# Altium schematic sheet [03] - MCU.SchDoc
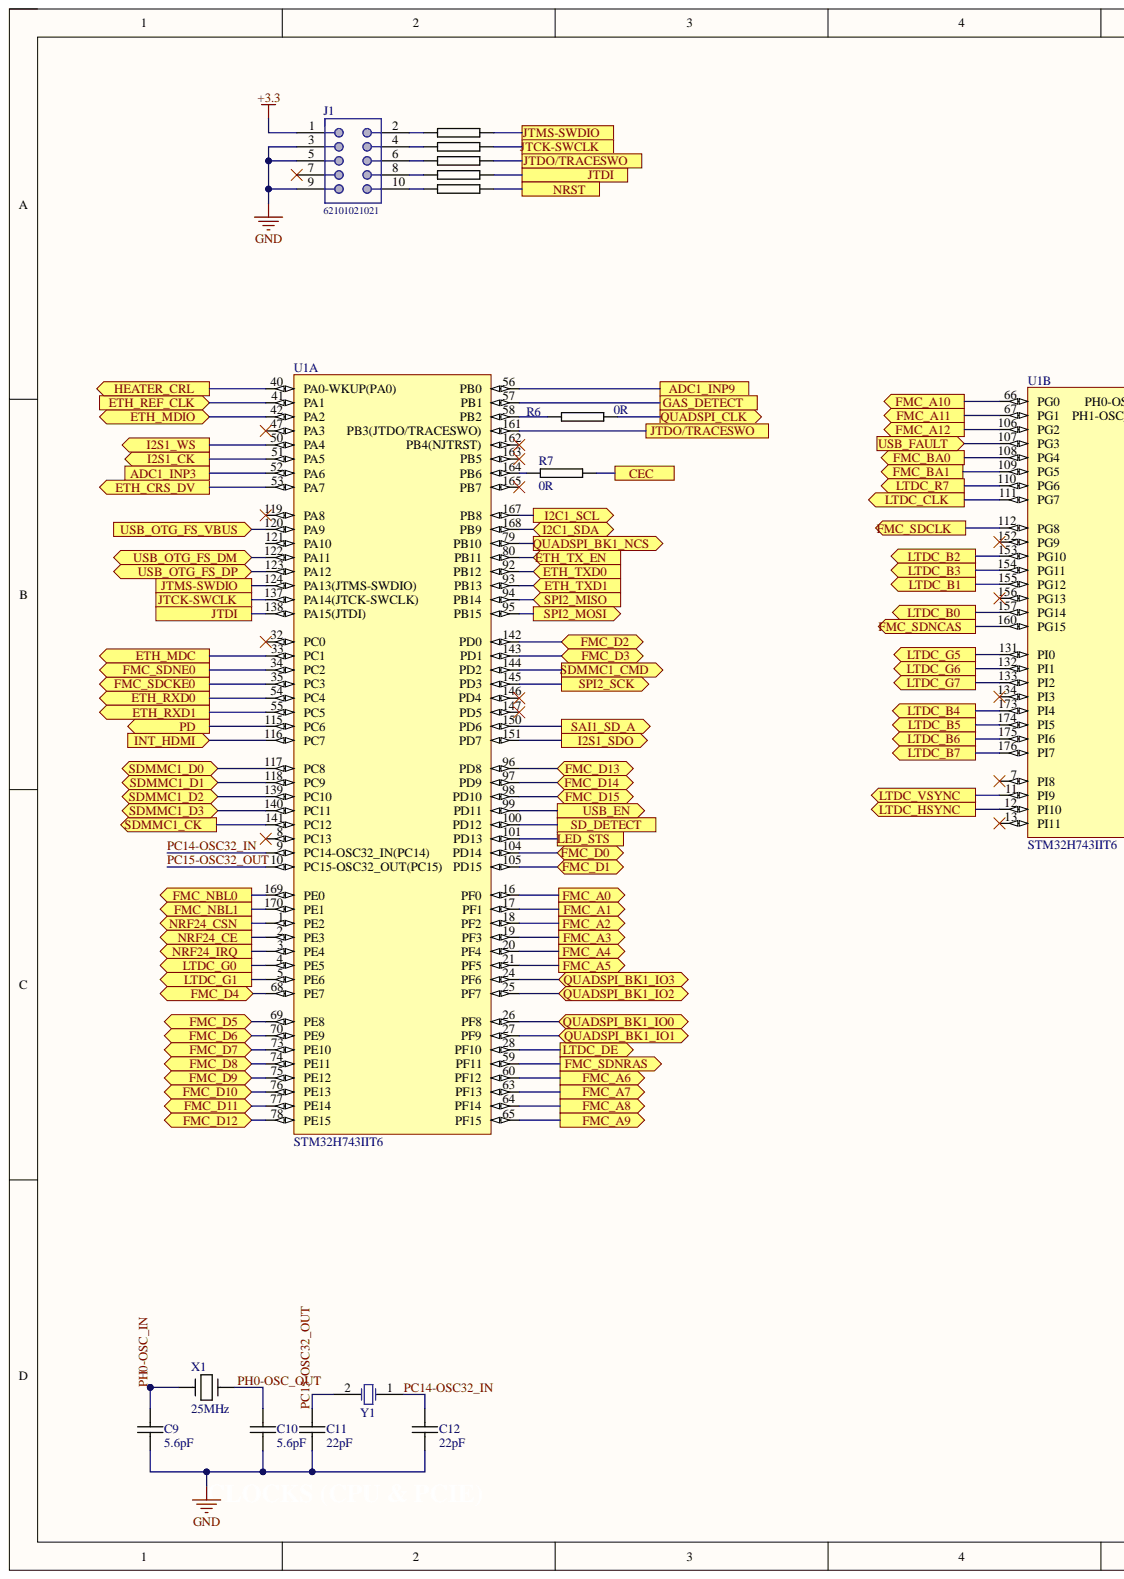
[diagram p0: [03] - MCU.SchDoc, left]
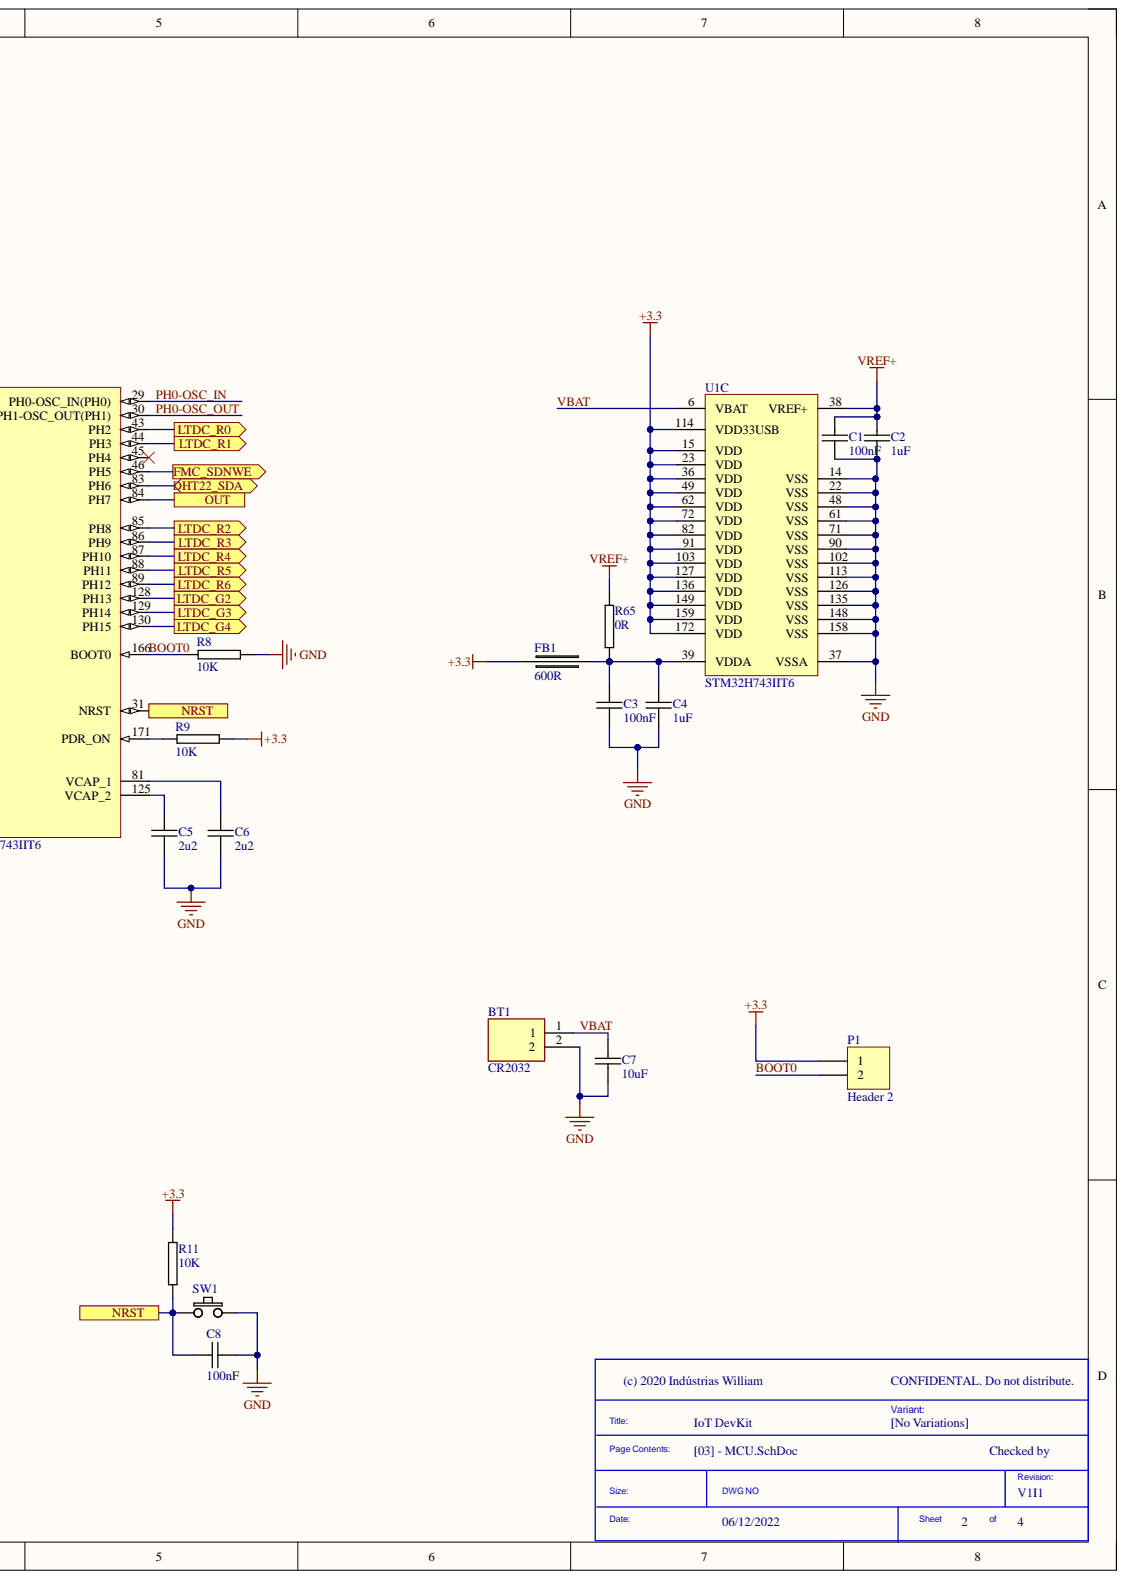
[diagram p1: [03] - MCU.SchDoc, right]

## Parts
BT1  CR2032  [socket_CR2032]
C1  100nF  [0603C-GENERIC]
C2  1uF  [0603C-GENERIC]
C3  100nF  [0603C-GENERIC]
C4  1uF  [0603C-GENERIC]
C5  2u2  [0603C-2U2]
C6  2u2  [0603C-2U2]
C7  10uF  [0603C-GENERIC]
C8  100nF  [0603C-GENERIC]
C9  5.6pF  [0603C-GENERIC]
C10  5.6pF  Reel: C10  [0603C-GENERIC]
C11  22pF  Reel: C10  [0603C-GENERIC]
C12  22pF  [0603C-GENERIC]
FB1  600R  [0603FB-GENERIC]
J1  62101021021  [62101021021]
P1  Header 2  [HDR1X2]
R1  33R  [0603R-33]  (value not drawn)
R2  33R  [0603R-33]  (value not drawn)
R3  33R  [0603R-33]  (value not drawn)
R4  33R  [0603R-33]  (value not drawn)
R5  33R  [0603R-33]  (value not drawn)
R6  0R  [0603R-0R]
R7  0R  [0603R-0R]
R8  10K  [0603R-10K]
R9  10K  [0603R-10K]
R11  10K  [0603R-10K]
R65  0R  [0603R-0R]
SW1  SW-PB-BLACK-SMD  [SW-PB-SMD]  (value not drawn)
U1  STM32H743IIT6  [STM-LQFP176_N]  (parts A,B,C)
X1  25MHz  [5032]
Y1  32.768kHz, +/-20ppm, 12.5pF  [WE-XTAL_CFPX-56]  (value not drawn)

## Ports
ADC1_INP3, ADC1_INP9, CEC, DHT22_SDA, ETH_CRS_DV, ETH_MDC, ETH_MDIO, ETH_REF_CLK, ETH_RXD0, ETH_RXD1, ETH_TX_EN, ETH_TXD0, ETH_TXD1, FMC_A0, FMC_A1, FMC_A2, FMC_A3, FMC_A4, FMC_A5, FMC_A6, FMC_A7, FMC_A8, FMC_A9, FMC_A10, FMC_A11, FMC_A12, FMC_BA0, FMC_BA1, FMC_D0, FMC_D1, FMC_D2, FMC_D3, FMC_D4, FMC_D5, FMC_D6, FMC_D7, FMC_D8, FMC_D9, FMC_D10, FMC_D11, FMC_D12, FMC_D13, FMC_D14, FMC_D15, FMC_NBL0, FMC_NBL1, FMC_SDCKE0, FMC_SDCLK, FMC_SDNCAS, FMC_SDNE0, FMC_SDNRAS, FMC_SDNWE, GAS_DETECT, HEATER_CRL, I2C1_SCL, I2C1_SDA, I2S1_CK, I2S1_SDO, I2S1_WS, INT_HDMI, JTCK-SWCLK, JTDI, JTDO/TRACESWO, JTMS-SWDIO, LED_STS, LTDC_B0, LTDC_B1, LTDC_B2, LTDC_B3, LTDC_B4, LTDC_B5, LTDC_B6, LTDC_B7, LTDC_CLK, LTDC_DE, LTDC_G0, LTDC_G1, LTDC_G2, LTDC_G3, LTDC_G4, LTDC_G5, LTDC_G6, LTDC_G7, LTDC_HSYNC, LTDC_R0, LTDC_R1, LTDC_R2, LTDC_R3, LTDC_R4, LTDC_R5, LTDC_R6, LTDC_R7, LTDC_VSYNC, NRF24_CE, NRF24_CSN, NRF24_IRQ, NRST, OUT, PD, QUADSPI_BK1_IO0, QUADSPI_BK1_IO1, QUADSPI_BK1_IO2, QUADSPI_BK1_IO3, QUADSPI_BK1_NCS, QUADSPI_CLK, SAI1_SD_A, SD_DETECT, SDMMC1_CK, SDMMC1_CMD, SDMMC1_D0, SDMMC1_D1, SDMMC1_D2, SDMMC1_D3, SPI2_MISO, SPI2_MOSI, SPI2_SCK, USB_EN, USB_FAULT, USB_OTG_FS_DM, USB_OTG_FS_DP, USB_OTG_FS_VBUS

## Nets
+3.3: FB1.1 J1.1 P1.1 R9.2 R11.2 U1.15(VDD) U1.23(VDD) U1.36(VDD) U1.49(VDD) U1.62(VDD) U1.72(VDD) U1.82(VDD) U1.91(VDD) U1.103(VDD) U1.114(VDD33USB) U1.127(VDD) U1.136(VDD) U1.149(VDD) U1.159(VDD) U1.172(VDD)
GND: BT1.2 C1.2 C2.2 C3.2 C4.2 C5.2 C6.2 C7.2 C8.2 C9.2 C10.2 C11.2 C12.2 J1.3 J1.5 J1.9 R8.2 SW1.2 U1.14(VSS) U1.22(VSS) U1.37(VSSA) U1.48(VSS) U1.61(VSS) U1.71(VSS) U1.90(VSS) U1.102(VSS) U1.113(VSS) U1.126(VSS) U1.135(VSS) U1.148(VSS) U1.158(VSS)
VREF+: C1.1 C2.1 R65.1 U1.38(VREF+)
ADC1_INP3: U1.52(PA6) ; port ADC1_INP3
ADC1_INP9: U1.56(PB0) ; port ADC1_INP9
BOOT0: P1.2 R8.1 U1.166(BOOT0)
CEC: R7.2 ; port CEC
DHT22_SDA: U1.83(PH6) ; port DHT22_SDA
ETH_CRS_DV: U1.53(PA7) ; port ETH_CRS_DV
ETH_MDC: U1.33(PC1) ; port ETH_MDC
ETH_MDIO: U1.42(PA2) ; port ETH_MDIO
ETH_REF_CLK: U1.41(PA1) ; port ETH_REF_CLK
ETH_RXD0: U1.54(PC4) ; port ETH_RXD0
ETH_RXD1: U1.55(PC5) ; port ETH_RXD1
ETH_TX_EN: U1.80(PB11) ; port ETH_TX_EN
ETH_TXD0: U1.92(PB12) ; port ETH_TXD0
ETH_TXD1: U1.93(PB13) ; port ETH_TXD1
FMC_A0: U1.16(PF0) ; port FMC_A0
FMC_A1: U1.17(PF1) ; port FMC_A1
FMC_A2: U1.18(PF2) ; port FMC_A2
FMC_A3: U1.19(PF3) ; port FMC_A3
FMC_A4: U1.20(PF4) ; port FMC_A4
FMC_A5: U1.21(PF5) ; port FMC_A5
FMC_A6: U1.60(PF12) ; port FMC_A6
FMC_A7: U1.63(PF13) ; port FMC_A7
FMC_A8: U1.64(PF14) ; port FMC_A8
FMC_A9: U1.65(PF15) ; port FMC_A9
FMC_A10: U1.66(PG0) ; port FMC_A10
FMC_A11: U1.67(PG1) ; port FMC_A11
FMC_A12: U1.106(PG2) ; port FMC_A12
FMC_BA0: U1.108(PG4) ; port FMC_BA0
FMC_BA1: U1.109(PG5) ; port FMC_BA1
FMC_D0: U1.104(PD14) ; port FMC_D0
FMC_D1: U1.105(PD15) ; port FMC_D1
FMC_D2: U1.142(PD0) ; port FMC_D2
FMC_D3: U1.143(PD1) ; port FMC_D3
FMC_D4: U1.68(PE7) ; port FMC_D4
FMC_D5: U1.69(PE8) ; port FMC_D5
FMC_D6: U1.70(PE9) ; port FMC_D6
FMC_D7: U1.73(PE10) ; port FMC_D7
FMC_D8: U1.74(PE11) ; port FMC_D8
FMC_D9: U1.75(PE12) ; port FMC_D9
FMC_D10: U1.76(PE13) ; port FMC_D10
FMC_D11: U1.77(PE14) ; port FMC_D11
FMC_D12: U1.78(PE15) ; port FMC_D12
FMC_D13: U1.96(PD8) ; port FMC_D13
FMC_D14: U1.97(PD9) ; port FMC_D14
FMC_D15: U1.98(PD10) ; port FMC_D15
FMC_NBL0: U1.169(PE0) ; port FMC_NBL0
FMC_NBL1: U1.170(PE1) ; port FMC_NBL1
FMC_SDCKE0: U1.35(PC3) ; port FMC_SDCKE0
FMC_SDCLK: U1.112(PG8) ; port FMC_SDCLK
FMC_SDNCAS: U1.160(PG15) ; port FMC_SDNCAS
FMC_SDNE0: U1.34(PC2) ; port FMC_SDNE0
FMC_SDNRAS: U1.59(PF11) ; port FMC_SDNRAS
FMC_SDNWE: U1.46(PH5) ; port FMC_SDNWE
GAS_DETECT: U1.57(PB1) ; port GAS_DETECT
HEATER_CRL: U1.40(PA0-WKUP(PA0)) ; port HEATER_CRL
I2C1_SCL: U1.167(PB8) ; port I2C1_SCL
I2C1_SDA: U1.168(PB9) ; port I2C1_SDA
I2S1_CK: U1.51(PA5) ; port I2S1_CK
I2S1_SDO: U1.151(PD7) ; port I2S1_SDO
I2S1_WS: U1.50(PA4) ; port I2S1_WS
INT_HDMI: U1.116(PC7) ; port INT_HDMI
JTCK-SWCLK: R2.1 U1.137(PA14(JTCK-SWCLK)) ; port JTCK-SWCLK
JTDI: R4.1 U1.138(PA15(JTDI)) ; port JTDI
JTDO/TRACESWO: R3.1 U1.161(PB3(JTDO/TRACESWO)) ; port JTDO/TRACESWO
JTMS-SWDIO: R1.1 U1.124(PA13(JTMS-SWDIO)) ; port JTMS-SWDIO
LED_STS: U1.101(PD13) ; port LED_STS
LTDC_B0: U1.157(PG14) ; port LTDC_B0
LTDC_B1: U1.155(PG12) ; port LTDC_B1
LTDC_B2: U1.153(PG10) ; port LTDC_B2
LTDC_B3: U1.154(PG11) ; port LTDC_B3
LTDC_B4: U1.173(PI4) ; port LTDC_B4
LTDC_B5: U1.174(PI5) ; port LTDC_B5
LTDC_B6: U1.175(PI6) ; port LTDC_B6
LTDC_B7: U1.176(PI7) ; port LTDC_B7
LTDC_CLK: U1.111(PG7) ; port LTDC_CLK
LTDC_DE: U1.28(PF10) ; port LTDC_DE
LTDC_G0: U1.4(PE5) ; port LTDC_G0
LTDC_G1: U1.5(PE6) ; port LTDC_G1
LTDC_G2: U1.128(PH13) ; port LTDC_G2
LTDC_G3: U1.129(PH14) ; port LTDC_G3
LTDC_G4: U1.130(PH15) ; port LTDC_G4
LTDC_G5: U1.131(PI0) ; port LTDC_G5
LTDC_G6: U1.132(PI1) ; port LTDC_G6
LTDC_G7: U1.133(PI2) ; port LTDC_G7
LTDC_HSYNC: U1.12(PI10) ; port LTDC_HSYNC
LTDC_R0: U1.43(PH2) ; port LTDC_R0
LTDC_R1: U1.44(PH3) ; port LTDC_R1
LTDC_R2: U1.85(PH8) ; port LTDC_R2
LTDC_R3: U1.86(PH9) ; port LTDC_R3
LTDC_R4: U1.87(PH10) ; port LTDC_R4
LTDC_R5: U1.88(PH11) ; port LTDC_R5
LTDC_R6: U1.89(PH12) ; port LTDC_R6
LTDC_R7: U1.110(PG6) ; port LTDC_R7
LTDC_VSYNC: U1.11(PI9) ; port LTDC_VSYNC
NRF24_CE: U1.2(PE3) ; port NRF24_CE
NRF24_CSN: U1.1(PE2) ; port NRF24_CSN
NRF24_IRQ: U1.3(PE4) ; port NRF24_IRQ
NRST: C8.1 R5.1 R11.1 SW1.1 U1.31(NRST) ; port NRST
OUT: U1.84(PH7) ; port OUT
PC14-OSC32_IN: C12.1 U1.9(PC14-OSC32_IN(PC14)) Y1.1
PC15-OSC32_OUT: C11.1 U1.10(PC15-OSC32_OUT(PC15)) Y1.2
PD: U1.115(PC6) ; port PD
PH0-OSC_IN: C9.1 U1.29(PH0-OSC_IN(PH0)) X1.1
PH0-OSC_OUT: C10.1 U1.30(PH1-OSC_OUT(PH1)) X1.2
QUADSPI_BK1_IO0: U1.26(PF8) ; port QUADSPI_BK1_IO0
QUADSPI_BK1_IO1: U1.27(PF9) ; port QUADSPI_BK1_IO1
QUADSPI_BK1_IO2: U1.25(PF7) ; port QUADSPI_BK1_IO2
QUADSPI_BK1_IO3: U1.24(PF6) ; port QUADSPI_BK1_IO3
QUADSPI_BK1_NCS: U1.79(PB10) ; port QUADSPI_BK1_NCS
QUADSPI_CLK: R6.2 ; port QUADSPI_CLK
SAI1_SD_A: U1.150(PD6) ; port SAI1_SD_A
SD_DETECT: U1.100(PD12) ; port SD_DETECT
SDMMC1_CK: U1.141(PC12) ; port SDMMC1_CK
SDMMC1_CMD: U1.144(PD2) ; port SDMMC1_CMD
SDMMC1_D0: U1.117(PC8) ; port SDMMC1_D0
SDMMC1_D1: U1.118(PC9) ; port SDMMC1_D1
SDMMC1_D2: U1.139(PC10) ; port SDMMC1_D2
SDMMC1_D3: U1.140(PC11) ; port SDMMC1_D3
SPI2_MISO: U1.94(PB14) ; port SPI2_MISO
SPI2_MOSI: U1.95(PB15) ; port SPI2_MOSI
SPI2_SCK: U1.145(PD3) ; port SPI2_SCK
USB_EN: U1.99(PD11) ; port USB_EN
USB_FAULT: U1.107(PG3) ; port USB_FAULT
USB_OTG_FS_DM: U1.122(PA11) ; port USB_OTG_FS_DM
USB_OTG_FS_DP: U1.123(PA12) ; port USB_OTG_FS_DP
USB_OTG_FS_VBUS: U1.120(PA9) ; port USB_OTG_FS_VBUS
VBAT: BT1.1 C7.1 U1.6(VBAT)
NetC3_1: C3.1 C4.1 FB1.2 R65.2 U1.39(VDDA)
NetC5_1: C5.1 U1.125(VCAP_2)
NetC6_1: C6.1 U1.81(VCAP_1)
NetJ1_2: J1.2 R1.2
NetJ1_4: J1.4 R2.2
NetJ1_6: J1.6 R3.2
NetJ1_8: J1.8 R4.2
NetJ1_10: J1.10 R5.2
NetR6_1: R6.1 U1.58(PB2)
NetR7_1: R7.1 U1.164(PB6)
NetR9_1: R9.1 U1.171(PDR_ON)

Not connected: J1 7; U1 7(PI8) 8(PC13) 13(PI11) 32(PC0) 45(PH4) 47(PA3) 119(PA8) 121(PA10) 134(PI3) 146(PD4) 147(PD5) 152(PG9) 156(PG13) 162(PB4(NJTRST)) 163(PB5) 165(PB7)

## Library data of the parts
BT1 = CR2032: Conector Battery CR2032; Manufacturer_Name: Wurth Elektronik; Manufacturer_Part_Number: 79527141; Arrow Part Number: 79527141; Component Kind: Standard; Datasheet Link: http://www.mouser.com/datasheet/2/445/79527141-1380512.pdf; Height: 5.9mm; Mouser Part Number: 710-79527141; Mouser Price/Stock: https://www.mouser.co.uk/ProductDetail/Wurth-Elektronik/79527141?qs=wr8lucFkNMXHgRaBtMvyBw%3D%3D; Library Name: Componentes Deived Projetos.IntLib
C1 C3 C8 = 0603C-100NF-25V-X7R-TDK: CAPACITOR 0603 CERAMIC; Manufacturer: TDK Corporation; Manufacturer Part Number: C1608X7R1E104K; Reel: C12; Component Kind: Standard; Library Name: vh_altium_library.intLib; Supplier 1: Digi-Key; Supplier Part Number 1: 445-1316-2-ND
C2 C4 = 0603C-1UF-10V-X5R-TDK: CAPACITOR 0603 CERAMIC; Manufacturer: TDK Corporation; Manufacturer Part Number: C1608X5R1A105K; Reel: C23; Component Kind: Standard; Library Name: vh_altium_library.intLib; Supplier 1: Digi-Key; Supplier Part Number 1: 445-1321-2-ND
C5 C6 = 0603C-2U2-10V-X5R-TDK: CAPACITOR 0603 CERAMIC; Manufacturer: TDK Corporation; Manufacturer Part Number: C1608X5R1A225K; Reel: C11; Component Kind: Standard; Library Name: vh_altium_library.intLib; Supplier 1: Digi-Key; Supplier Part Number 1: 445-5166-2-ND
C7 = 0603C-10UF-10V-X5R-TDK: CAPACITOR 0603 CERAMIC; Manufacturer: TDK Corporation; Manufacturer Part Number: C1608X5R0J106M; Reel: C31; Component Kind: Standard; Library Name: vh_altium_library.intLib; Supplier 1: Digi-Key; Supplier Part Number 1: 445-4112-2-ND
C9 = 0603C-22PF-50V-C0G-PAN: CAPACITOR 0603 CERAMIC; Manufacturer: Panasonic - ECG; Manufacturer Part Number: ECJ-1VC1H220J; Capacitance: 22pF; Reel: C10; Temperature Coefficient: C0G, NP0; Tolerance: ±5%; Voltage - Rated: 50V; Category: Capacitors; Component Kind: Standard; Features: General Purpose; Lead Spacing: -; Library Name: vh_altium_library.intLib; Mounting Type: Surface Mount, MLCC; Operating Temperature: -55°C ~ 125°C; Package / Case: 0603 (1608 metric); Packaging: Tape & Reel (TR); RoHS: RoHS Compliant; Supplier: Digi-Key; Supplier 1: Digi-Key; Supplier Part Number: PCC220ACVTR-ND; Supplier Part Number 1: PCC220ACVTR-ND; Thickness: 0.80mm
C10 = 0603C-22PF-50V-C0G-PAN: CAPACITOR 0603 CERAMIC; Manufacturer: Panasonic - ECG; Manufacturer Part Number: ECJ-1VC1H220J; Capacitance: 22pF; Temperature Coefficient: C0G, NP0; Tolerance: ±5%; Voltage - Rated: 50V; Category: Capacitors; Component Kind: Standard; Features: General Purpose; Lead Spacing: -; Library Name: vh_altium_library.intLib; Mounting Type: Surface Mount, MLCC; Operating Temperature: -55°C ~ 125°C; Package / Case: 0603 (1608 metric); Packaging: Tape & Reel (TR); RoHS: RoHS Compliant; Supplier: Digi-Key; Supplier 1: Digi-Key; Supplier Part Number: PCC220ACVTR-ND; Supplier Part Number 1: PCC220ACVTR-ND; Thickness: 0.80mm
C11 = 0603C-22PF-50V-C0G-PAN: CAPACITOR 0603 CERAMIC; Manufacturer: Panasonic - ECG; Manufacturer Part Number: ECJ-1VC1H220J; Temperature Coefficient: C0G, NP0; Tolerance: ±5%; Voltage - Rated: 50V; Capacitance: 22pF; Category: Capacitors; Component Kind: Standard; Features: General Purpose; Lead Spacing: -; Library Name: vh_altium_library.intLib; Mounting Type: Surface Mount, MLCC; Operating Temperature: -55°C ~ 125°C; Package / Case: 0603 (1608 metric); Packaging: Tape & Reel (TR); RoHS: RoHS Compliant; Supplier: Digi-Key; Supplier 1: Digi-Key; Supplier Part Number: PCC220ACVTR-ND; Supplier Part Number 1: PCC220ACVTR-ND; Thickness: 0.80mm
C12 = 0603C-22PF-50V-C0G-PAN: CAPACITOR 0603 CERAMIC; Manufacturer: Panasonic - ECG; Manufacturer Part Number: ECJ-1VC1H220J; Reel: C10; Temperature Coefficient: C0G, NP0; Tolerance: ±5%; Voltage - Rated: 50V; Capacitance: 22pF; Category: Capacitors; Component Kind: Standard; Features: General Purpose; Lead Spacing: -; Library Name: vh_altium_library.intLib; Mounting Type: Surface Mount, MLCC; Operating Temperature: -55°C ~ 125°C; Package / Case: 0603 (1608 metric); Packaging: Tape & Reel (TR); RoHS: RoHS Compliant; Supplier: Digi-Key; Supplier 1: Digi-Key; Supplier Part Number: PCC220ACVTR-ND; Supplier Part Number 1: PCC220ACVTR-ND; Thickness: 0.80mm
FB1 = 0603FB-GENERIC: FERRITE BEAD SMD; Component Kind: Standard; Library Name: vh_altium_library.intLib
J1 = 62101021021: WR-PHD Pin Header, SMT, pitch 1.27mm, Dual Row, Vertical, 10pin; Contact Resistance: 20mOhm; Gender: Male; Pin Number: 10; Rated Current: 1A; Withstanding Voltage: 500VAC; Working Voltage: 100VAC; Component Kind: Standard; ComponentLink1Description: Manufacturer; ComponentLink1URL: http://www.we-online.de; ComponentLink2Description: Datasheet; ComponentLink2URL: https://katalog.we-online.de/em/datasheet/62101021021.pdf; Library Name: Header Conector 2.54, 1.27.IntLib; Mounting Technology: SMT; Operating Temperature: -40 to +105degC; Orientation: Vertical; Packaging: Tube; Part Number: 62101021021; Pitch: 1.27mm; Published Date: June 2019; Row Number: Dual; Publisher: Wurth Elektronik
P1 = Header 2: Header, 2-Pin; Component Kind: Standard; LatestRevisionDate: 17-Jul-2002; LatestRevisionNote: Re-released for DXP Platform.; Library Name: Miscellaneous Connectors.IntLib; Signal Integrity: Connector; Publisher: Altium Limited
R1-R5 = 0603R-33: GENERIC RESISTOR 0603; Manufacturer: Stackpole Electronics Inc; Manufacturer Part Number: RMC 1/16 33 1% G; Power (Watts): 0.1W, 1/10W; Reel: R18; Resistance In Ohms: 33.0; Temperature Coefficient: ±100ppm/°C; Tolerance: ±1%; Case: 0603 (1608 metric); Category: Resistors; Component Kind: Standard; Composition: Thick Film; Lead Style: Surface Mount (SMD - SMT); Library Name: vh_altium_library.intLib; Packaging: Tape & Reel (TR); RoHS: RoHS Compliant; Supplier: Digi-Key; Supplier 1: Digi-Key; Supplier Part Number: RMC1/1633FG-ND; Supplier Part Number 1: RMCF0603FT33R0TR-ND
R6 R7 R65 = 0603R-0R: GENERIC JUMPER 0603; Manufacturer: Stackpole Electronics Inc; Manufacturer Part Number: RMC 1/16 0 G; Reel: R26; Component Kind: Standard; Library Name: vh_altium_library.intLib; Supplier 1: Digi-Key; Supplier Part Number 1: RMCF0603ZT0R00TR-ND
R8 R9 R11 = 0603R-10K: GENERIC RESISTOR 0603; Manufacturer: Stackpole Electronics Inc; Manufacturer Part Number: RMCF 1/16 10K 1% R; Power (Watts): 0.1W, 1/10W; Reel: R21; Resistance In Ohms: 10.0K; Temperature Coefficient: ±100ppm/°C; Tolerance: ±1%; Case: 0603 (1608 metric); Category: Resistors; Component Kind: Standard; Composition: Thick Film; Lead Style: Surface Mount (SMD - SMT); Library Name: vh_altium_library.intLib; Packaging: Digi-Reel®; RoHS: RoHS Compliant; Supplier: Digi-Key; Supplier 1: Digi-Key; Supplier Part Number: RMCF1/1610KFRDKR-ND; Supplier Part Number 1: RMCF0603FT10K0DKR-ND
SW1 = SW-PB-BLACK-SMD: PUSHBUTTON SWITCH 6MM SMD; Component Kind: Standard; Library Name: vh_altium_library.intLib
U1 = STM32H743IIT6: ARM Cortex-M47 32-bit MCU+FPU, 225 DMIPS, 2048 kB Flash, 256 kB Internal RAM, 140 I/Os, 176-pin LQFP, -40 to 85 degC, Tray; Manufacturer: STMicroelectronics; PartNumber: STM32F429IIT6; D/A Converter: 2x12-bit; FLASH Size (Prog) (kB): 2048; I/Os (High Current): 140; Internal RAM Size (kB): 256; Operating Frequency(F) (Processor speed) (MHz): 180; Supply Voltage(Vcc) max (V): 3.6; Supply Voltage(Vcc) min (V): 1.8; Component Kind: Standard; ComponentLink1Description: Manufacturer URL; ComponentLink1URL: http://www.st.com/web/en/home.html; ComponentLink2Description: Datasheet; ComponentLink2URL: http://www.st.com/st-web-ui/static/active/en/resource/technical/document/data_brief/DM00053488.pdf; ComponentLink3Description: Package Specification; ComponentLink3URL: http://www.st.com/st-web-ui/static/active/en/resource/technical/document/datasheet/DM00035129.pdf; Core: ARM Cortex-M4; DatasheetVersion: Rev. 2; Mounting Technology: Surface Mount; PackageDescription: 176-Pin Low Profile Quad Flat Package, 24 x 24 mm Body, 0.5 mm Pitch; PackageReference: LQFP176; PackageVersion: Rev. 4, 06/2013; Packing: Tray; Supplier 1: Digi-Key; Supplier 2: Newark; Supplier 3: Mouser; Supplier Part Number 1: 497-14054-ND; Supplier Part Number 2: 06X3672; Supplier Part Number 3: 511-STM32F429IIT6; Library Name: Componentes Deived Projetos.IntLib
X1 = 16MC-HC49US: 16MHZ CRSYTAL HC49US; Component Kind: Standard; Library Name: vh_altium_library.intLib; Supplier 1: Mouser; Supplier Part Number 1: 815-ABLS-16.0M-T
Y1 = 830025159: WE-XTAL Quartz Crystal, size CFPX-56, 32.768kHz, +/-20ppm, 12.5pF; Component Kind: Standard; ComponentLink1Description: Manufacturer; ComponentLink1URL: http://www.we-online.de; ComponentLink2Description: Datasheet; ComponentLink2URL: https://katalog.we-online.com/pbs/datasheet/830025159.pdf; Frequency: 32.768kHz; Frequency Stability: -; Frequency Tolerance: +/-20ppm; Library Name: ALTIUM-CFPX-180-rev19a.IntLib; Load Capacitance: 12.5pF; Mounting Technology: SMT; Operating Temperature: -40 up to +85degC; Packaging: Tape and Reel; Part Number: 830025159; Published Date: March 2019; Size: CFPX-56; Publisher: Wurth Elektronik
